# Altium schematic sheet power.SchDoc(power)
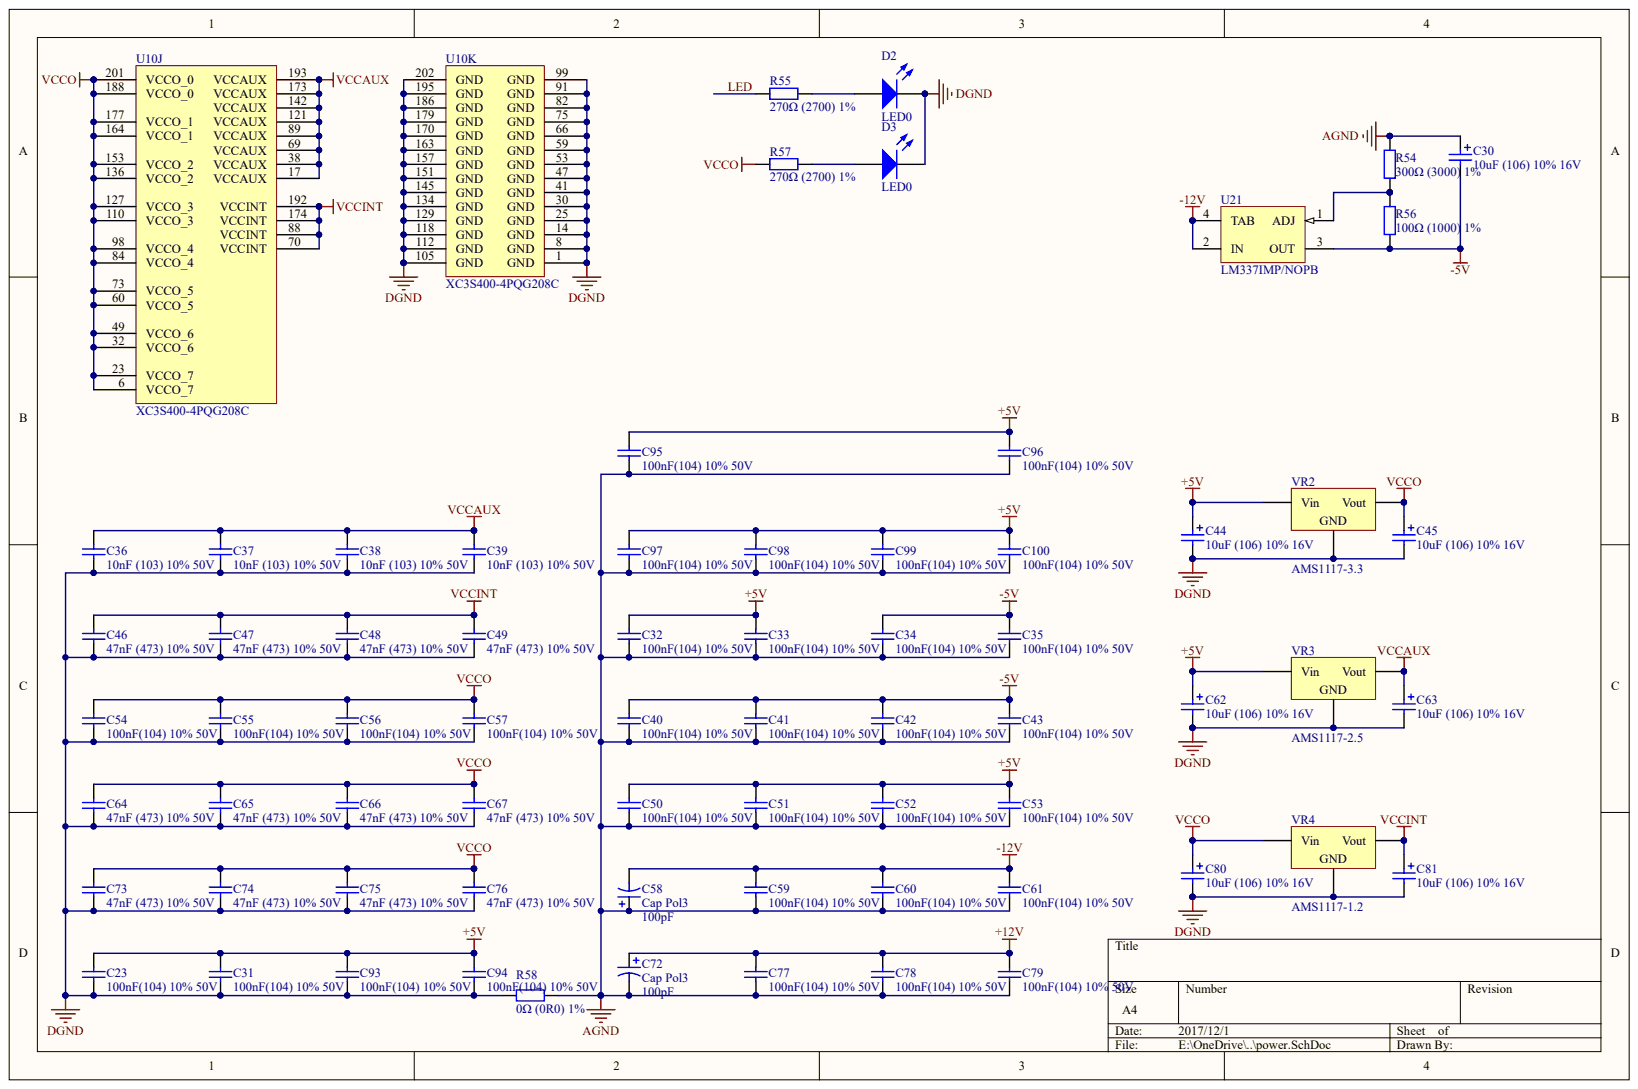
[diagram p0: power.SchDoc]

## Parts
C23  100nF(104) 10% 50V
C30  10uF (106) ±10% 16V
C31  100nF(104) 10% 50V
C32  100nF(104) 10% 50V
C33  100nF(104) 10% 50V
C34  100nF(104) 10% 50V
C35  100nF(104) 10% 50V
C36  10nF (103) 10% 50V
C37  10nF (103) 10% 50V
C38  10nF (103) 10% 50V
C39  10nF (103) 10% 50V
C40  100nF(104) 10% 50V
C41  100nF(104) 10% 50V
C42  100nF(104) 10% 50V
C43  100nF(104) 10% 50V
C44  10uF (106) ±10% 16V
C45  10uF (106) ±10% 16V
C46  47nF (473) 10% 50V
C47  47nF (473) 10% 50V
C48  47nF (473) 10% 50V
C49  47nF (473) 10% 50V
C50  100nF(104) 10% 50V
C51  100nF(104) 10% 50V
C52  100nF(104) 10% 50V
C53  100nF(104) 10% 50V
C54  100nF(104) 10% 50V
C55  100nF(104) 10% 50V
C56  100nF(104) 10% 50V
C57  100nF(104) 10% 50V
C58  Cap Pol3  value 100pF
C59  100nF(104) 10% 50V
C60  100nF(104) 10% 50V
C61  100nF(104) 10% 50V
C62  10uF (106) ±10% 16V
C63  10uF (106) ±10% 16V
C64  47nF (473) 10% 50V
C65  47nF (473) 10% 50V
C66  47nF (473) 10% 50V
C67  47nF (473) 10% 50V
C72  Cap Pol3  value 100pF
C73  47nF (473) 10% 50V
C74  47nF (473) 10% 50V
C75  47nF (473) 10% 50V
C76  47nF (473) 10% 50V
C77  100nF(104) 10% 50V
C78  100nF(104) 10% 50V
C79  100nF(104) 10% 50V
C80  10uF (106) ±10% 16V
C81  10uF (106) ±10% 16V
C93  100nF(104) 10% 50V
C94  100nF(104) 10% 50V
C95  100nF(104) 10% 50V
C96  100nF(104) 10% 50V
C97  100nF(104) 10% 50V
C98  100nF(104) 10% 50V
C99  100nF(104) 10% 50V
C100  100nF(104) 10% 50V
D2  LED0
D3  LED0
R54  300Ω (3000) ±1%
R55  270Ω (2700) ±1%
R56  100Ω (1000) ±1%
R57  270Ω (2700) ±1%
R58  0Ω (0R0) ±1%
U10  XC3S400-4PQG208C  (parts J,K)
U21  LM337IMP/NOPB
VR2  AMS1117-3.3
VR3  AMS1117-2.5
VR4  AMS1117-1.2

## Nets
+5V: C23.1 C31.1 C32.1 C33.1 C44.1 C50.1 C51.1 C52.1 C53.1 C62.1 C93.1 C94.1 C95.1 C96.1 C97.1 C98.1 C99.1 C100.1 VR2.3 VR3.3
+12V: C72.1 C77.1 C78.1 C79.1
-5V: C30.2 C34.1 C35.1 C40.1 C41.1 C42.1 C43.1 R56.1 U21.3(OUT)
-12V: C58.2 C59.1 C60.1 C61.1 U21.2(IN) U21.4(TAB)
AGND: C30.1 C32.2 C33.2 C34.2 C35.2 C40.2 C41.2 C42.2 C43.2 C50.2 C51.2 C52.2 C53.2 C58.1 C59.2 C60.2 C61.2 C72.2 C77.2 C78.2 C79.2 C95.2 C96.2 C97.2 C98.2 C99.2 C100.2 R54.2 R58.2
DGND: C23.2 C31.2 C36.1 C37.1 C38.1 C39.1 C44.2 C45.2 C46.1 C47.1 C48.1 C49.1 C54.2 C55.2 C56.2 C57.2 C62.2 C63.2 C64.1 C65.1 C66.1 C67.1 C73.1 C74.1 C75.1 C76.1 C80.2 C81.2 C93.2 C94.2 D2.2 D3.2 R58.1 U10.1(GND) U10.8(GND) U10.14(GND) U10.25(GND) U10.30(GND) U10.41(GND) U10.47(GND) U10.53(GND) U10.59(GND) U10.66(GND) U10.75(GND) U10.82(GND) U10.91(GND) U10.99(GND) U10.105(GND) U10.112(GND) U10.118(GND) U10.129(GND) U10.134(GND) U10.145(GND) U10.151(GND) U10.157(GND) U10.163(GND) U10.170(GND) U10.179(GND) U10.186(GND) U10.195(GND) U10.202(GND) VR2.1 VR3.1 VR4.1
VCCAUX: C36.2 C37.2 C38.2 C39.2 C63.1 U10.17(VCCAUX) U10.38(VCCAUX) U10.69(VCCAUX) U10.89(VCCAUX) U10.121(VCCAUX) U10.142(VCCAUX) U10.173(VCCAUX) U10.193(VCCAUX) VR3.2
VCCINT: C46.2 C47.2 C48.2 C49.2 C81.1 U10.70(VCCINT) U10.88(VCCINT) U10.174(VCCINT) U10.192(VCCINT) VR4.2
VCCO: C45.1 C54.1 C55.1 C56.1 C57.1 C64.2 C65.2 C66.2 C67.2 C73.2 C74.2 C75.2 C76.2 C80.1 R57.1 U10.6(VCCO_7) U10.23(VCCO_7) U10.32(VCCO_6) U10.49(VCCO_6) U10.60(VCCO_5) U10.73(VCCO_5) U10.84(VCCO_4) U10.98(VCCO_4) U10.110(VCCO_3) U10.127(VCCO_3) U10.136(VCCO_2) U10.153(VCCO_2) U10.164(VCCO_1) U10.177(VCCO_1) U10.188(VCCO_0) U10.201(VCCO_0) VR2.2 VR4.3
LED: R55.1
NetD2_1: D2.1 R55.2
NetD3_1: D3.1 R57.2
NetR54_1: R54.1 R56.2 U21.1(ADJ)

## Library data of the parts
U10 = (no symbol name): Ordering Information: Additional code may have to be appended for different mask revision devices.; FirstPublished: 16-Dec-2005; LatestRevisionDate: 05-Mar-2009; LatestRevisionNote: Updated to datasheet version 2.4 Jun-2008.; DatasheetVersion: ver2.4, Jun-2008; PackageVersion: ver1.2, Jun-2004; PackageReference: PQG208; PackageDescription: 208-Pin Plastic Quad Flat Pack (PQG208) - Pb-Free; Supplier 1: Digi-Key; Supplier Part Number 1: 122-1519-ND; Code_JEDEC: MS-029-FA-1; ComponentLink1Description: Spartan-3 Datasheet; ComponentLink1URL: http://www.xilinx.com/support/documentation/data_sheets/ds099.pdf; ComponentLink2Description: PQG208 Package Drawing; ComponentLink2URL: http://www.xilinx.com/support/documentation/package_specs/pq208.pdf; ComponentLink3Description: Spartan-3 Documents; ComponentLink3URL: http://www.xilinx.com/support/documentation/spartan-3.htm; ComponentLink4Description: Xilinx.com Home; ComponentLink4URL: http://www.xilinx.com; Publisher: Altium Limited
U21 = (no symbol name): Manufacturer: National Semiconductor; PackageTopMarking: XYTT*N02A; PowerWise: N; CompType: LDO IC; Published: 1-Mar-2010; DatasheetVersion: Nov-2004; PackageVersion: revB, Apr-2003; PackageReference: MP04A; PackageDescription: Molded SOT-223, 6.50 x 3.56 x 1.6mm Body, 4 Lead, 2.3mm Pitch; Supplier 1: Digi-Key; Supplier Part Number 1: LM337IMPTR-ND; Supplier 2: Digi-Key; Supplier Part Number 2: LM337IMPCT-ND; Supplier 3: Digi-Key; Supplier Part Number 3: LM337IMPDKR-ND; Supplier 4: Newark; Supplier Part Number 4: 41K4742; PartNumber: LM337IMP/NOPB; RoHS: Y; PinCount: 4; Code_JEDEC: TO-261-AA; Code_IPC: SOT223-4; ComponentLink1Description: LM337 Datasheet; ComponentLink1URL: http://www.national.com/ds/LM/LM337.pdf; ComponentLink2Description: LM337 Web Page; ComponentLink2URL: http://www.national.com/pf/LM/LM337.html; ComponentLink3Description: MP04A Package Page; ComponentLink3URL: http://www.national.com/packaging/folders/mp04a.html; Publisher: Altium Limited
C23 C30-C67 C72-C81 C93-C100 D2 D3 R54-R58 VR2-VR4 = (no symbol name)
  C23 C31-C35 C40-C43 C50-C57 C59-C61 C77-C79 C93-C100: ComponentLink1Description: 供应商链接; ComponentLink1URL: http://www.szlcsc.com/product/details_50686.html; Package: 0805; Supplier: LC; SuppliersPartNumber: C49678
  C30 C44 C45 C62 C63 C80 C81: ComponentLink1Description: 供应商链接; ComponentLink1URL: http://www.szlcsc.com/product/details_7643.html; Package: 3216; Supplier: LC; SuppliersPartNumber: C7171
  C36-C39: ComponentLink1Description: 供应商链接; ComponentLink1URL: http://www.szlcsc.com/product/details_2062.html; Package: 0805; Supplier: LC; SuppliersPartNumber: C1710
  C46-C49 C64-C67 C73-C76: ComponentLink1Description: 供应商链接; ComponentLink1URL: http://www.szlcsc.com/product/details_54150.html; Package: 0805; Supplier: LC; SuppliersPartNumber: C53134
  C58 C72: Published: 8-Jun-2000; LatestRevisionDate: 29-May-2009; LatestRevisionNote: IPC-7351 Footprint Added.; PackageReference: C0805; PackageDescription: Chip Capacitor
  D2 D3: Published: 8-Jun-2000; LatestRevisionDate: 08-Jul-2005; LatestRevisionNote: Mech Layer 1 removed.; PackageReference: LED-0; PackageDescription: LED; 2 Leads
  R54: ComponentLink1Description: 供应商链接; ComponentLink1URL: http://www.szlcsc.com/product/details_18305.html; Package: 0805; Supplier: LC; SuppliersPartNumber: C17617
  R55 R57: ComponentLink1Description: 供应商链接; ComponentLink1URL: http://www.szlcsc.com/product/details_18278.html; Package: 0805; Supplier: LC; SuppliersPartNumber: C17590
  R56: ComponentLink1Description: 供应商链接; ComponentLink1URL: http://www.szlcsc.com/product/details_18096.html; Package: 0805; Supplier: LC; SuppliersPartNumber: C17408
  R58: ComponentLink1Description: 供应商链接; ComponentLink1URL: http://www.szlcsc.com/product/details_18165.html; Package: 0805; Supplier: LC; SuppliersPartNumber: C17477
  VR2-VR4: ComponentLink1Description: 供应商链接; ComponentLink1URL: http://www.szlcsc.com/product/details_6652.html; Package: SOT-223; Supplier: LC; SuppliersPartNumber: C6186
  C58 C72 D2 D3: Publisher: Altium Limited
